# Altium schematic sheet io.SchDoc
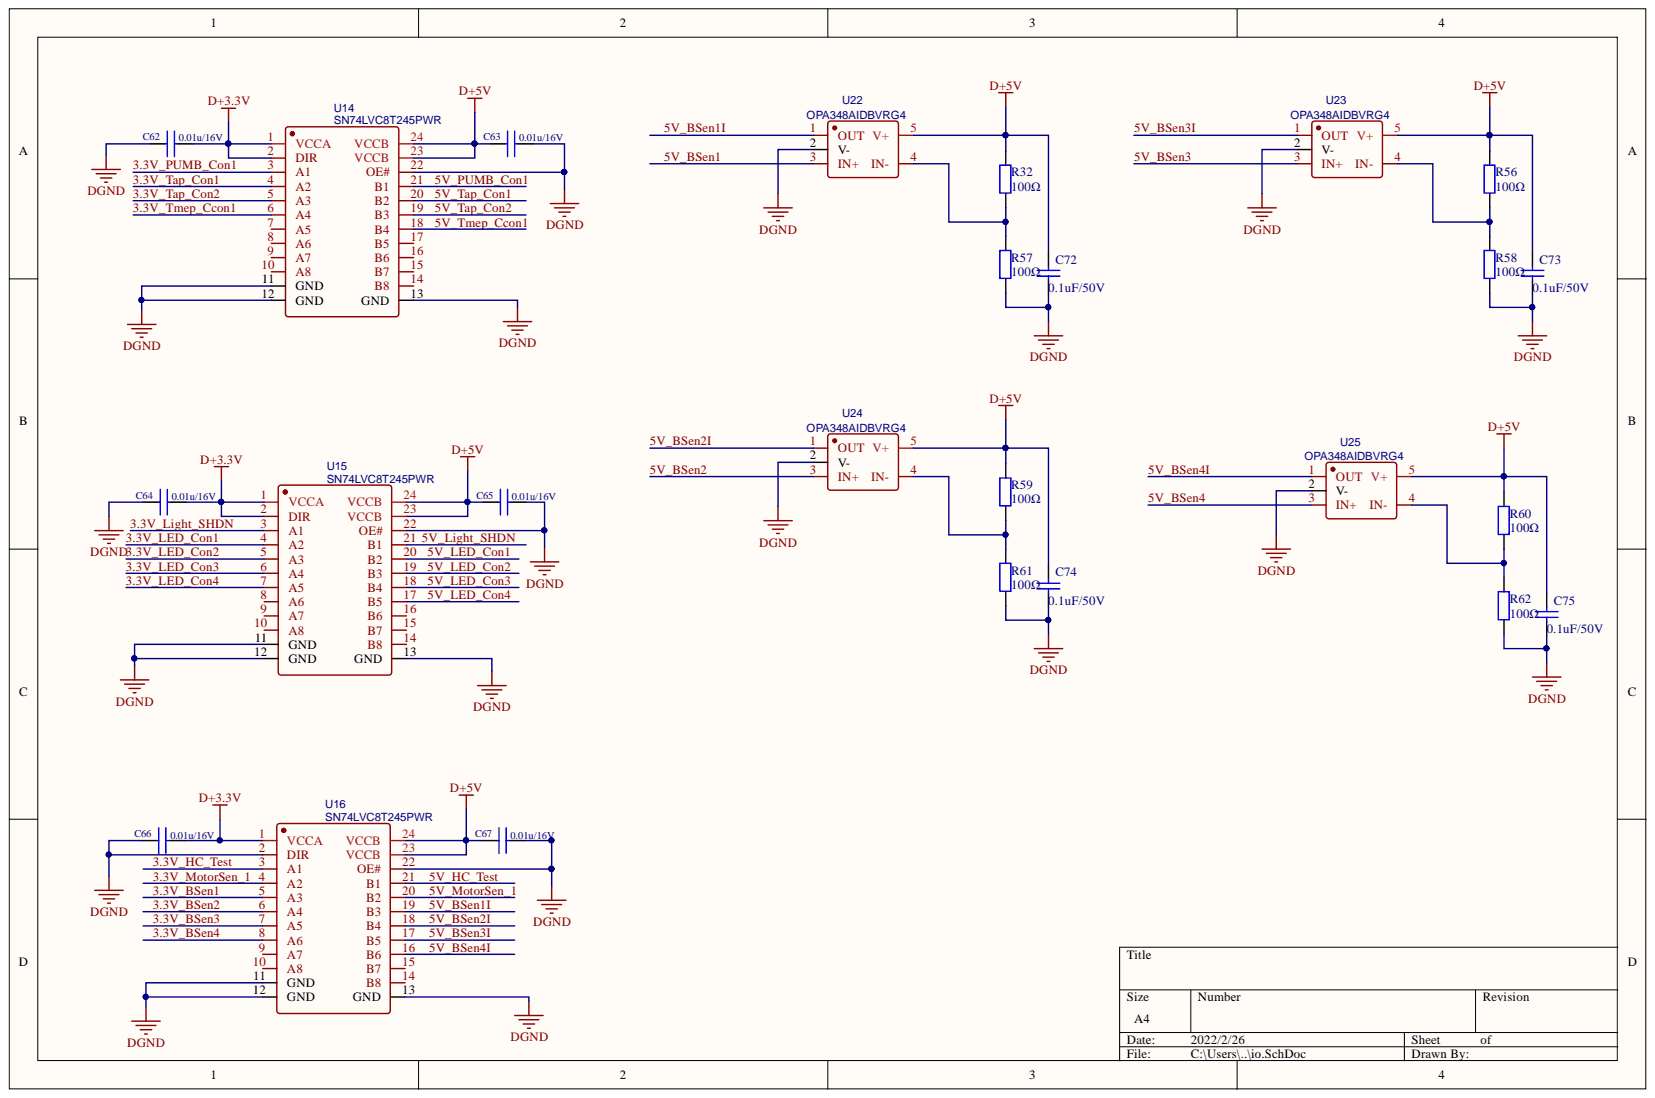
[diagram p0: io.SchDoc]

## Parts
C62  0.01u/16V  [C0603]
C63  0.01u/16V  [C0603]
C64  0.01u/16V  [C0603]
C65  0.01u/16V  [C0603]
C66  0.01u/16V  [C0603]
C67  0.01u/16V  [C0603]
C72  0.1uF/50V  [C0603]
C73  0.1uF/50V  [C0603]
C74  0.1uF/50V  [C0603]
C75  0.1uF/50V  [C0603]
R32  100Ω  [R0603]
R56  100Ω  [R0603]
R57  100Ω  [R0603]
R58  100Ω  [R0603]
R59  100Ω  [R0603]
R60  100Ω  [R0603]
R61  100Ω  [R0603]
R62  100Ω  [R0603]
U14  SN74LVC8T245PWR  [TSSOP-24]
U15  SN74LVC8T245PWR  [TSSOP-24]
U16  SN74LVC8T245PWR  [TSSOP-24]
U22  OPA348AIDBVRG4  [SOT-23-5]
U23  OPA348AIDBVRG4  [SOT-23-5]
U24  OPA348AIDBVRG4  [SOT-23-5]
U25  OPA348AIDBVRG4  [SOT-23-5]

## Nets
D+3.3V: C62.2 C64.2 C66.2 U14.1(VCCA) U14.2(DIR) U15.1(VCCA) U15.2(DIR) U16.1(VCCA)
D+5V: C63.1 C65.1 C67.1 C72.2 C73.2 C74.2 C75.2 R32.2 R56.2 R59.2 R60.2 U14.23(VCCB) U14.24(VCCB) U15.23(VCCB) U15.24(VCCB) U16.23(VCCB) U16.24(VCCB) U22.5(V+) U23.5(V+) U24.5(V+) U25.5(V+)
DGND: C62.1 C63.2 C64.1 C65.2 C66.1 C67.2 C72.1 C73.1 C74.1 C75.1 R57.1 R58.1 R61.1 R62.1 U14.11(GND) U14.12(GND) U14.13(GND) U14.22(OE#) U15.11(GND) U15.12(GND) U15.13(GND) U15.22(OE#) U16.2(DIR) U16.11(GND) U16.12(GND) U16.13(GND) U16.22(OE#) U22.2(V-) U23.2(V-) U24.2(V-) U25.2(V-)
3.3V_BSen1: U16.5(A3)
3.3V_BSen2: U16.6(A4)
3.3V_BSen3: U16.7(A5)
3.3V_BSen4: U16.8(A6)
3.3V_HC_Test: U16.3(A1)
3.3V_LED_Con1: U15.4(A2)
3.3V_LED_Con2: U15.5(A3)
3.3V_LED_Con3: U15.6(A4)
3.3V_LED_Con4: U15.7(A5)
3.3V_Light_SHDN: U15.3(A1)
3.3V_MotorSen_1: U16.4(A2)
3.3V_PUMB_Con1: U14.3(A1)
3.3V_Tap_Con1: U14.4(A2)
3.3V_Tap_Con2: U14.5(A3)
3.3V_Tmep_Ccon1: U14.6(A4)
5V_BSen1: U22.3(IN+)
5V_BSen1I: U16.19(B3) U22.1(OUT)
5V_BSen2: U24.3(IN+)
5V_BSen2I: U16.18(B4) U24.1(OUT)
5V_BSen3: U23.3(IN+)
5V_BSen3I: U16.17(B5) U23.1(OUT)
5V_BSen4: U25.3(IN+)
5V_BSen4I: U16.16(B6) U25.1(OUT)
5V_HC_Test: U16.21(B1)
5V_LED_Con1: U15.20(B2)
5V_LED_Con2: U15.19(B3)
5V_LED_Con3: U15.18(B4)
5V_LED_Con4: U15.17(B5)
5V_Light_SHDN: U15.21(B1)
5V_MotorSen_1: U16.20(B2)
5V_PUMB_Con1: U14.21(B1)
5V_Tap_Con1: U14.20(B2)
5V_Tap_Con2: U14.19(B3)
5V_Tmep_Ccon1: U14.18(B4)
NetR32_1: R32.1 R57.2 U22.4(IN-)
NetR56_1: R56.1 R58.2 U23.4(IN-)
NetR59_1: R59.1 R61.2 U24.4(IN-)
NetR60_1: R60.1 R62.2 U25.4(IN-)

Not connected: U14 7(A5) 8(A6) 9(A7) 10(A8) 14(B8) 15(B7) 16(B6) 17(B5); U15 8(A6) 9(A7) 10(A8) 14(B8) 15(B7) 16(B6); U16 9(A7) 10(A8) 14(B8) 15(B7)

## Library data of the parts
C62-C67 = CAP NP 0805: 0.1u/16V; Component Kind: Standard; Library Name: C:\Users\Lenovo\Desktop\定量PCR\电路图\PD板\PD.PcbLib
C72-C75 = Cap: Capacitor; Value: 10uF/10V; Component Kind: Standard; LatestRevisionDate: 17-Jul-2002; LatestRevisionNote: Re-released for DXP Platform.; Library Name: LIB-T.SCHLIB; PackageDescription: Radial Cap, Thru-Hole; 2 Leads; 0.3 in Pin Spacing; PackageReference: RAD-0.3; Published: 8-Jun-2000; Simulation: CAP; Publisher: Altium Limited
R32 R56-R62 = 0603 1.5KΩ (1501) 1%: 贴片电阻; Component Kind: Standard; ComponentLink1Description: 供应商链接; ComponentLink1URL: http://www.szlcsc.com/product/details_23570.html; Library Name: RES.SchLib; Package: 0603; Supplier: LC; SuppliersPartNumber: C22843
U14-U16 = SN74LVC8T245PWR: BOM_Manufacturer: TI; BOM_JLCPCB Part Class: Extended Part; BOM_Manufacturer Part: SN74LVC8T245PWR; BOM_Supplier: LCSC; BOM_Supplier Part: C27643; Component Kind: Standard; spicePre: U; spiceSymbolName: SN74LVC8T245PWR
U22-U25 = OPA348AIDBVRG4: BOM_Manufacturer: TI; SMT Type: Extend; BOM_JLCPCB SMD: Yes; BOM_Manufacturer Part: OPA348AIDBVRG4; BOM_Supplier: LCSC; BOM_Supplier Part: C46142; Component Kind: Standard; image: //image.lceda.cn/szlcsc/C46142.jpg; spicePre: U; spiceSymbolName: OPA348AIDBVRG4
